# Altium schematic sheet Main.SchDoc(Main)
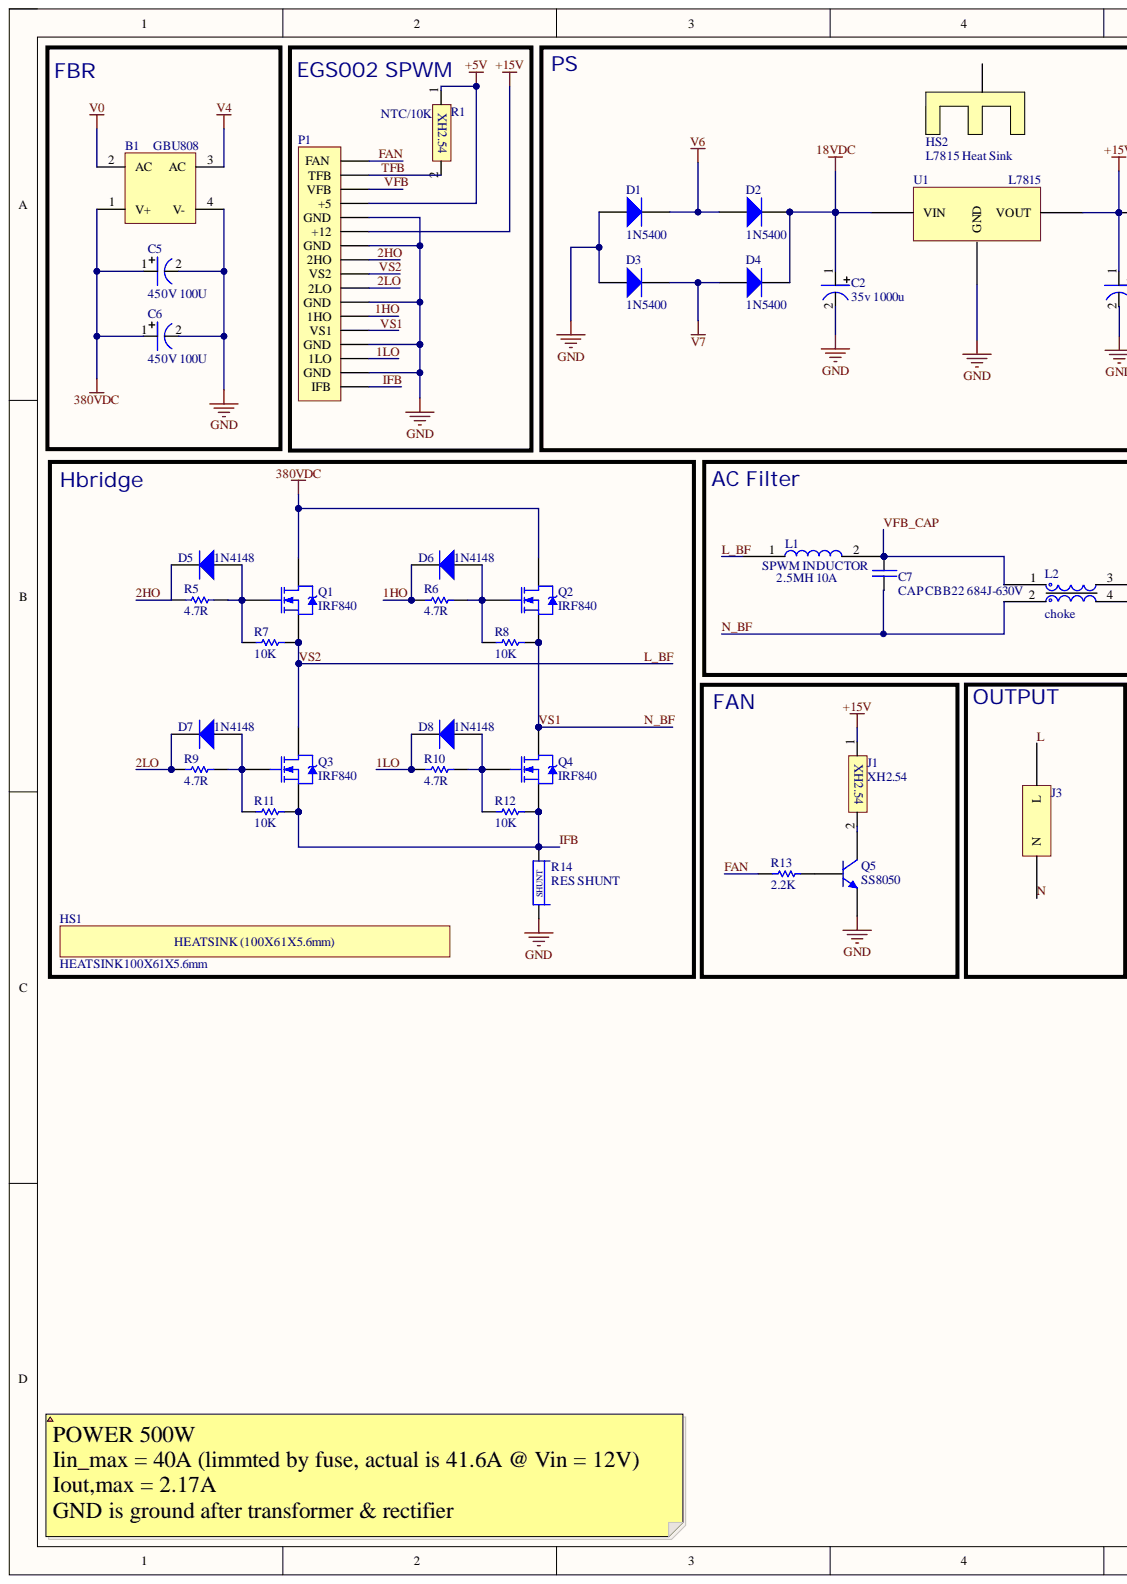
[diagram p0: Main.SchDoc, left]
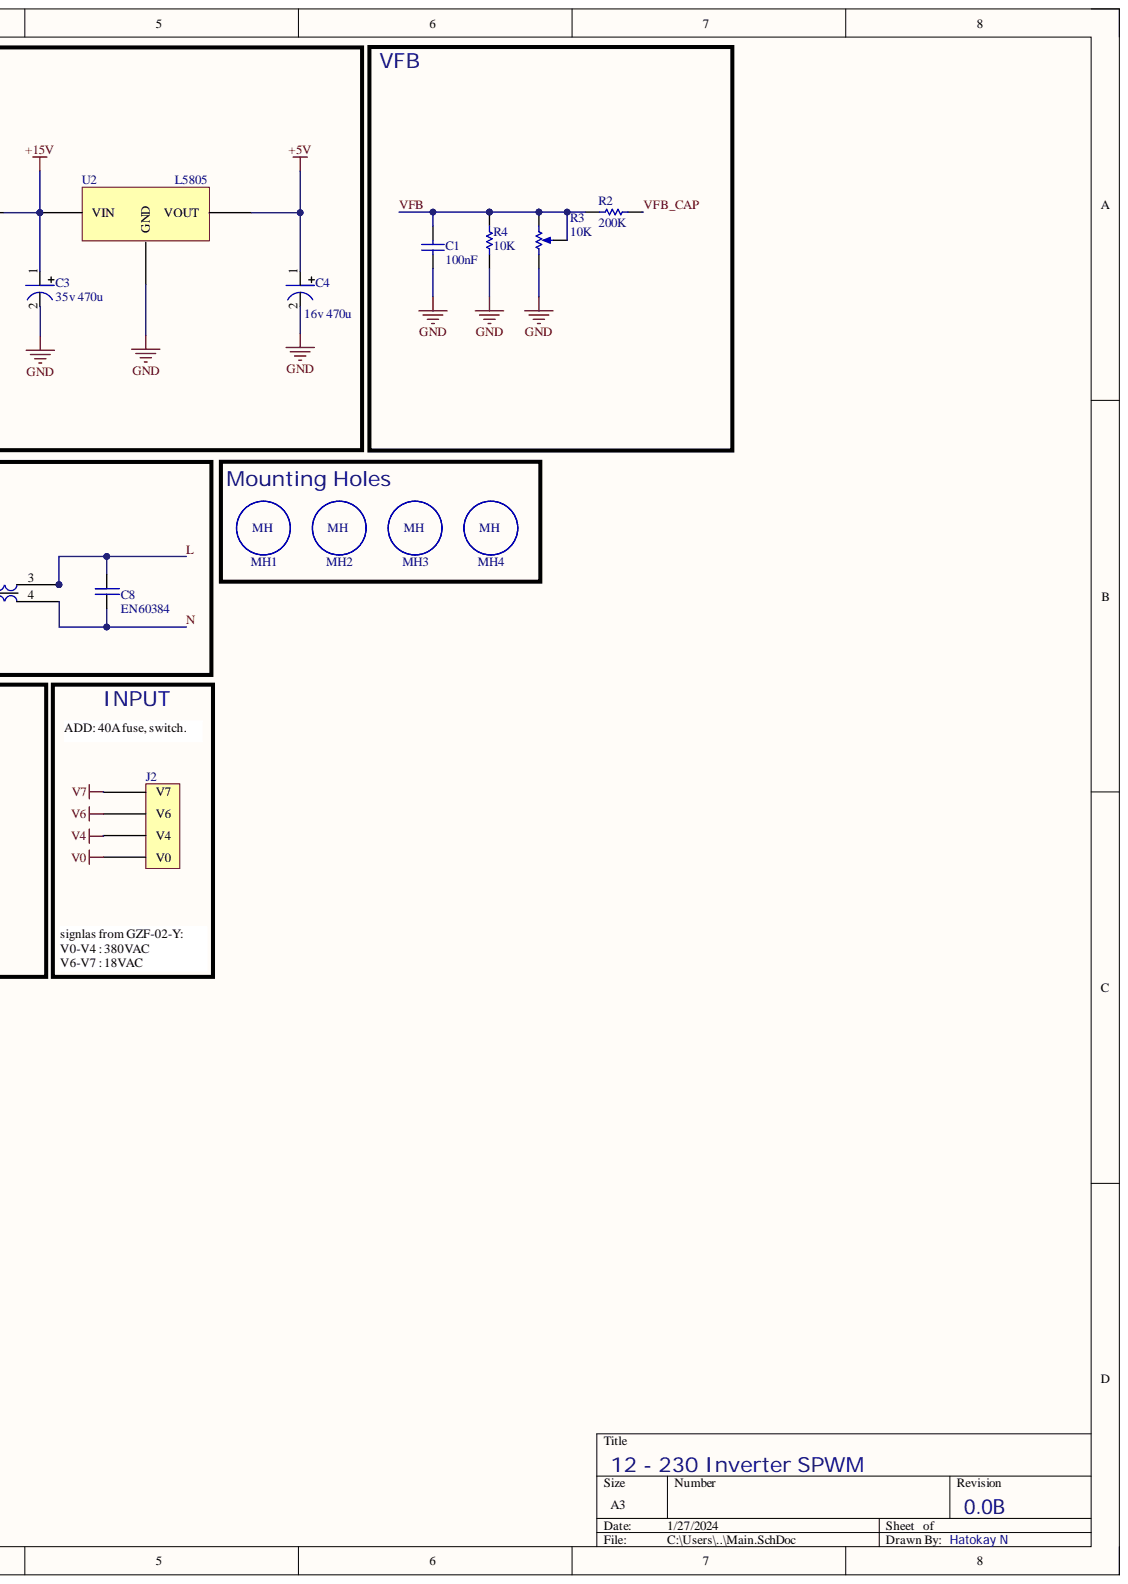
[diagram p1: Main.SchDoc, right]

## Parts
B1  GBU808  [My_KBU]
C1  100nF  [My_cap100n]
C2  35v 1000u  [CAP_POL_10X5.5X0.7]
C3  35v 470u  [CAP_POL_10X5.5X0.7]
C4  16v 470u  [CAP_POL_8.2X4X0.5]
C5  450V 100U  [CAP_POL_18X7.9X0.8]
C6  450V 100U  [CAP_POL_18X7.9X0.8]
C7  CAP CBB22 684J-630V  [CAP CBB22 684J-630V]
C8  EN60384  [CAP EN60384]
D1  1N5400  [My_DO201-15]
D2  1N5400  [My_DO201-15]
D3  1N5400  [My_DO201-15]
D4  1N5400  [My_DO201-15]
D5  1N4148  [DO-35]
D6  1N4148  [DO-35]
D7  1N4148  [DO-35]
D8  1N4148  [DO-35]
HS1  HEATSINK100X61X5.6mm  [HEATSINK100X61X5.6mm]
HS2  L7815 Heat Sink  [My_Heat_sink_TO22]
J1  XH2.54  [XH2.54CON]
J2  GZF-02-Y TO INV CON  [GZF-02-Y TO INV CON]  (value not drawn)
J3  AC L/N wire CON  [AC L/N wire CON]  (value not drawn)
L1  SPWM INDUCTOR  value 2.5MH 10A  [SPWM INDUCTOR]
L2  choke  [choke]
MH1  3mm Mounting Hole  [3mm Mouning Hole]  (value not drawn)
MH2  3mm Mounting Hole  [3mm Mouning Hole]  (value not drawn)
MH3  3mm Mounting Hole  [3mm Mouning Hole]  (value not drawn)
MH4  3mm Mounting Hole  [3mm Mouning Hole]  (value not drawn)
P1  Header 17  [MY_FE17]  (value not drawn)
Q1  IRF840  [TO-220AB]
Q2  IRF840  [TO-220AB]
Q3  IRF840  [TO-220AB]
Q4  IRF840  [TO-220AB]
Q5  SS8050  [TO-92A]
R1  NTC/10K  [XH2.54CON]
R2  200K  [MY_(0.5w)_0411_15]
R3  10K  [MY_TRIM3296W]
R4  10K  [MY_(0.5w)_0411_15]
R5  4.7R  [MY_(0.5w)_0411_15]
R6  4.7R  [MY_(0.5w)_0411_15]
R7  10K  [MY_(0.5w)_0411_15]
R8  10K  [MY_(0.5w)_0411_15]
R9  4.7R  [MY_(0.5w)_0411_15]
R10  4.7R  [MY_(0.5w)_0411_15]
R11  10K  [MY_(0.5w)_0411_15]
R12  10K  [MY_(0.5w)_0411_15]
R13  2.2K  [MY_(0.5w)_0411_15]
R14  RES SHUNT  [RES SHUNT]
U1  L7815  [LM78XX(ST)]
U2  L5805  [LM78XX(ST)]

## Nets
18VDC: C2.1 D2.K D4.K U1.1
380VDC: B1.1(V+) C5.1 C6.1 Q1.2 Q2.2
+5V: C4.1 P1.14(+5) R1.1 U2.3
+15V: C3.1 J1.1 P1.12(+12) U1.3 U2.1
GND: B1.4(V-) C1.1 C2.2 C3.2 C4.2 C5.2 C6.2 D1.A D3.A P1.2(GND) P1.4(GND) P1.7(GND) P1.11(GND) P1.13(GND) Q5.1 R3.1 R4.2 R14.1 U1.2 U2.2
V0: B1.2(AC) J2.1(V0)
V4: B1.3(AC) J2.2(V4)
V6: D1.K D2.A J2.3(V6)
V7: D3.K D4.A J2.4(V7)
1HO: D6.2 P1.6(1HO) R6.1
1LO: D8.2 P1.3(1LO) R10.1
2HO: D5.2 P1.10(2HO) R5.1
2LO: D7.2 P1.8(2LO) R9.1
FAN: P1.17(FAN) R13.1
IFB: P1.1(IFB) Q3.3 Q4.3 R11.2 R12.2 R14.2
L: C8.2 J3.1 L2.3
L_BF: L1.1 P1.9(VS2) Q1.3 Q3.2 R7.2
N: C8.1 J3.2 L2.4
N_BF: C7.1 L2.2 P1.5(VS1) Q2.3 Q4.2 R8.2
TFB: P1.16(TFB) R1.2
VFB: C1.2 P1.15(VFB) R2.1 R3.2 R3.3 R4.1
VFB_CAP: C7.2 L1.2 L2.1 R2.2
NetD5_1: D5.1 Q1.1 R5.2 R7.1
NetD6_1: D6.1 Q2.1 R6.2 R8.1
NetD7_1: D7.1 Q3.1 R9.2 R11.1
NetD8_1: D8.1 Q4.1 R10.2 R12.1
NetJ1_2: J1.2 Q5.3
NetQ5_2: Q5.2 R13.2

Not connected: HS2 1

## Library data of the parts
B1 = BRIDGE KBU: BRIDGE KBU 6 Amp; ASiD Part Number: BRIDGE KBU-AS; Component Kind: Standard; Library Name: Mylib+Rev+4 (1).IntLib
C1 = Cap ceramic: None polar capacitor; ASiD Part Number: C-AS; Component Kind: Standard; Library Name: Mylib+Rev+4 (1).IntLib; Value: 100nF
C2 C3 = CAP_POL_10X5.5X0.7: Component Kind: Standard; Description: CAP_POL_10X5.5X0.7; Library Name: Noah_LIB.IntLib
C4 = CAP_POL_8.2X4X0.5: Component Kind: Standard; Description: CAP_POL_8.2X4X0.5; Library Name: Noah_LIB.IntLib
C5 C6 = CAP_POL_18X7.9X0.8: Component Kind: Standard; Description: CAP_POL_18X7.9X0.8; Library Name: Noah_LIB.IntLib
C8 = CAP EN60384: EN60384 CAP  PIN DIA = 0.6mm  Inner PIN spacing = 9.45 mm   PACKGE: 16x11.3x5.85mm; Component Kind: Standard; Library Name: Noah_LIB.IntLib
D1-D4 = 1N5400: 3 Amp Diode; ASiD Part Number: 1N5408-AS; Component Kind: Standard; Library Name: Mylib+Rev+4 (1).IntLib
D5-D8 = Diode 1N4148: High Conductance Fast Diode; Code_JEDEC: DO-204-AH; Component Kind: Standard; ComponentLink1Description: Manufacturer Link; ComponentLink1URL: http://www.fairchildsemi.com/; ComponentLink2Description: Datasheet; ComponentLink2URL: http://www.fairchildsemi.com/ds/1N%2F1N4148.pdf; DatasheetVersion: 1997; LatestRevisionDate: 17-Jul-2002; LatestRevisionNote: Re-released for DXP Platform.; Library Name: Miscellaneous Devices.IntLib; PackageDescription: Diode, Axial; Body 3.8 x 1.9 mm (LxDia. max), Lead Dia. 0.53 mm (max); PackageReference: DO-35; Published: 8-Jun-2000; Signal Integrity: 1N4148; Simulation: 1N4148; Publisher: Altium Limited
HS1 = HEATSINK100X61X5.6mm: HEATSINK 100X61X5.6mm; Component Kind: Standard; Library Name: Noah_LIB.IntLib
HS2 = Heat  sink: ASiD Part Number: Heat  sink-AS; Component Kind: Standard; Description: Heat  sink; Library Name: Mylib+Rev+4 (1).IntLib
J1 R1 = XH2.54: XH2.54 CON; Component Kind: Standard; Library Name: Noah_LIB.IntLib
J3 = AC L/N wire CON: connector for AC wire (D=1mm)  just two holes 2mm in D.; Component Kind: Standard; Library Name: Noah_LIB.IntLib
L1 = SPWM INDUCTOR: 2.5MH 10A 51.5X17.2X23mm; Component Kind: Standard; Library Name: Noah_LIB.IntLib
L2 = choke: 22*14*8 2MH 1.0 Line Common mode Filter inductance Choke coil Annular common mode inductance 10A; Component Kind: Standard; Library Name: Noah_LIB.IntLib
MH1-MH4 = 3mm Mounting Hole: 3mm MH; Component Kind: Standard; Library Name: Noah_LIB.IntLib
P1 = Header 17: Header, 17-Pin; ASiD Part Number: Header 17-AS; Component Kind: Standard; Library Name: Mylib+Rev+4 (1).IntLib; Signal Integrity: Connector
Q1-Q4 = IRF840: HEXFET N-Channel Power MOSFET; Note: Pin 2 is electrically connected to pin 4.; Component Kind: Standard; ComponentLink1Description: Manufacturer Link; ComponentLink1URL: http://www.irf.com/; ComponentLink2Description: Datasheet; ComponentLink2URL: http://www.irf.com/product-info/datasheets/data/irf840.pdf; DatasheetVersion: PD-9.376H; LatestRevisionDate: 17-Jul-2002; LatestRevisionNote: Re-released for DXP Platform.; Library Name: IR Discrete MOSFET - Power.IntLib; PackageDescription: TO, Thru-Hole, Vertical, Heatsink Mounted; 3 In-Line Leads; Pitch 2.54 mm; PackageReference: TO-220AB; PackageVersion: Feb-1997; Published: 8-Jun-2000; Simulation: IRF840; Publisher: Altium Limited
Q5 = 2N3904: NPN Epitaxial Silicon Transistor   IC = 1.5A  VCBO MAX = 40V  VCEO MAX = 25V  VEBO MAX = 6V; Component Kind: Standard; ComponentLink1Description: Manufacturer Link; ComponentLink1URL: http://www.fairchildsemi.com/; ComponentLink2Description: Datasheet; ComponentLink2URL: http://www.fairchildsemi.com/ds/2N%2F2N3904.pdf; DatasheetVersion: 1997; LatestRevisionDate: 17-Jan-2003; LatestRevisionNote: PCB Footprint replaced; Library Name: FSC Discrete BJT.IntLib; PackageDescription: TO, Flat Index; 3 In-Line, Axial Leads; Body Dia. 4.5mm; Leads 0.51 x 0.48 mm (max); PackageReference: TO-92A; PackageVersion: Sep-1998; Published: 8-Jun-2000; Signal Integrity: 2N3904; Simulation: 2N3904; Publisher: Altium Limited
R2 R4-R13 = Res: Standard resistor; ASiD Part Number: Res-AS; Component Kind: Standard; Library Name: Mylib+Rev+4 (1).IntLib
  R2: Value: 200K
  R4 R7 R8 R11 R12: Value: 10K
  R5 R6 R9 R10: Value: 4.7R
  R13: Value: 2.2K
R3 = Variable Resistor: Potentiometer; ASiD Part Number: Variable Resistor-AS; Component Kind: Standard; Library Name: Mylib+Rev+4 (1).IntLib; Value: 10K
U1 U2 = L78XX(ST): LM78XX(ST); Component Kind: Standard; Library Name: Noah_LIB.IntLib
C7 = CAP CBB22 684J-630V: Component Kind: Standard; Description: CAP CBB22 684J-630V; Library Name: Noah_LIB.IntLib
J2 = GZF-02-Y TO INV CON: Component Kind: Standard; Description: GZF-02-Y TO INV CON; Library Name: Noah_LIB.IntLib
R14 = RES SHUNT: Component Kind: Standard; Description: RES SHUNT; Library Name: Noah_LIB.IntLib
